# Altium schematic sheet Switches + Blocks.SchDoc("Switches + Blocks")
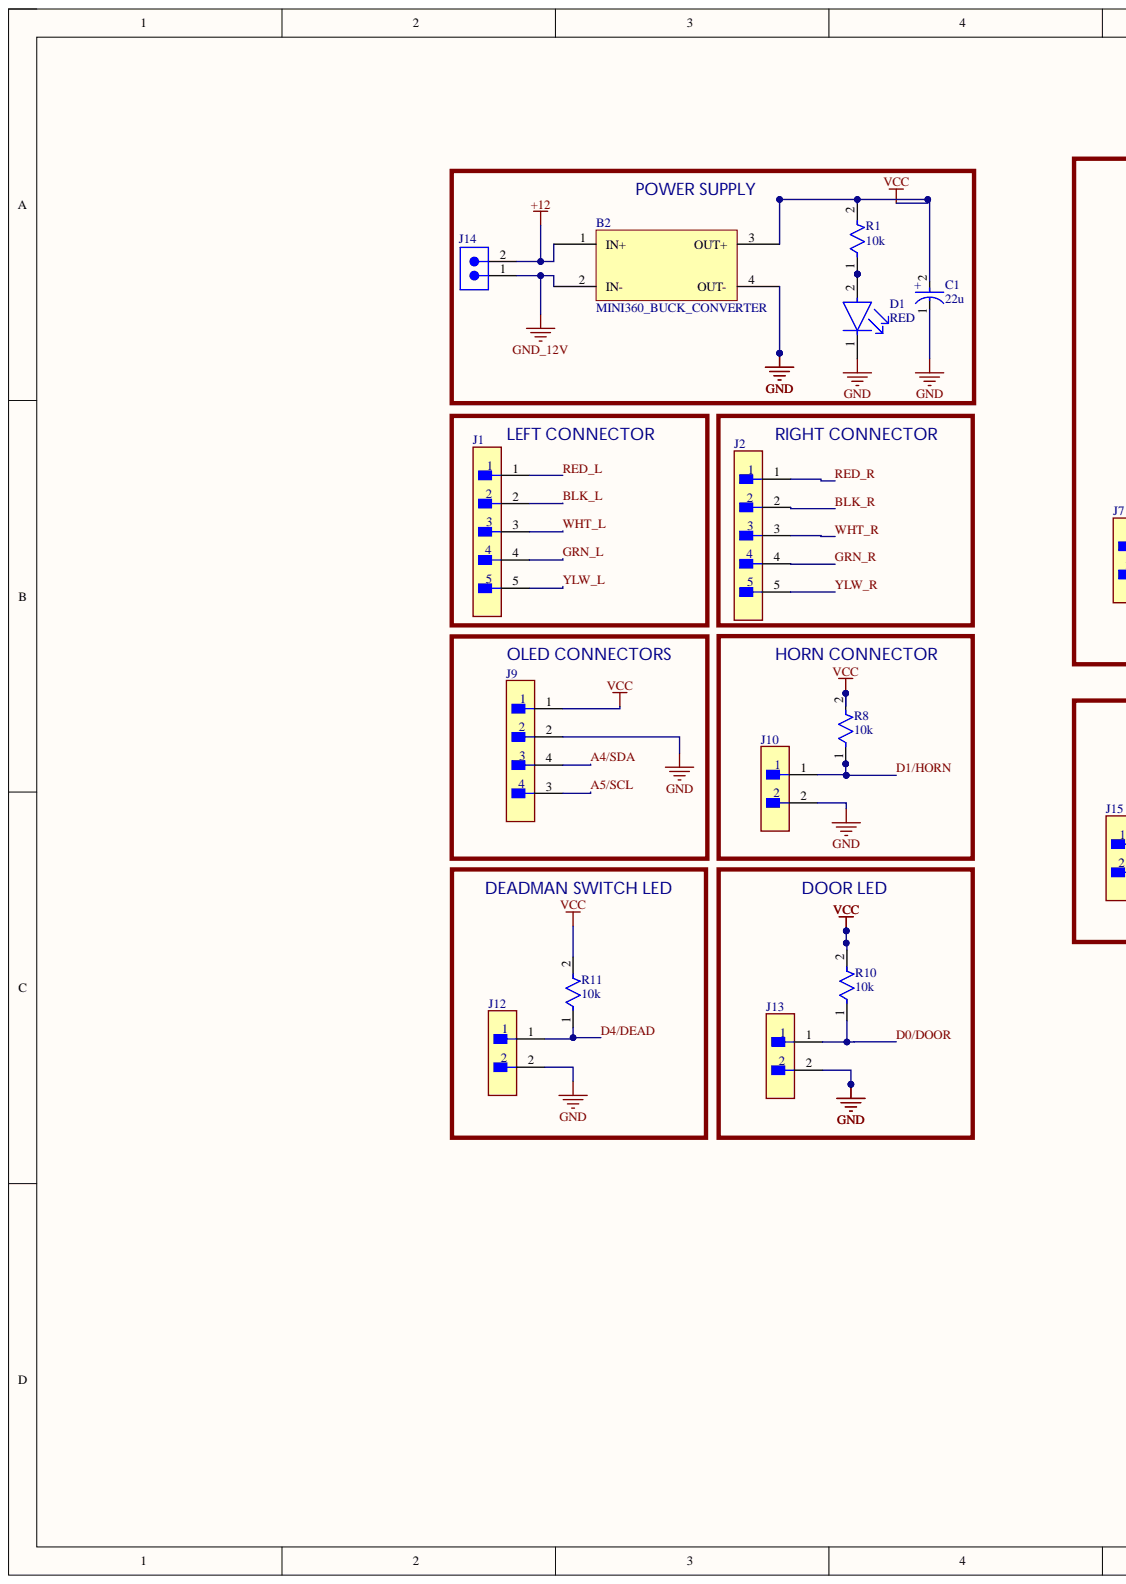
[diagram p0: Switches + Blocks.SchDoc, left]
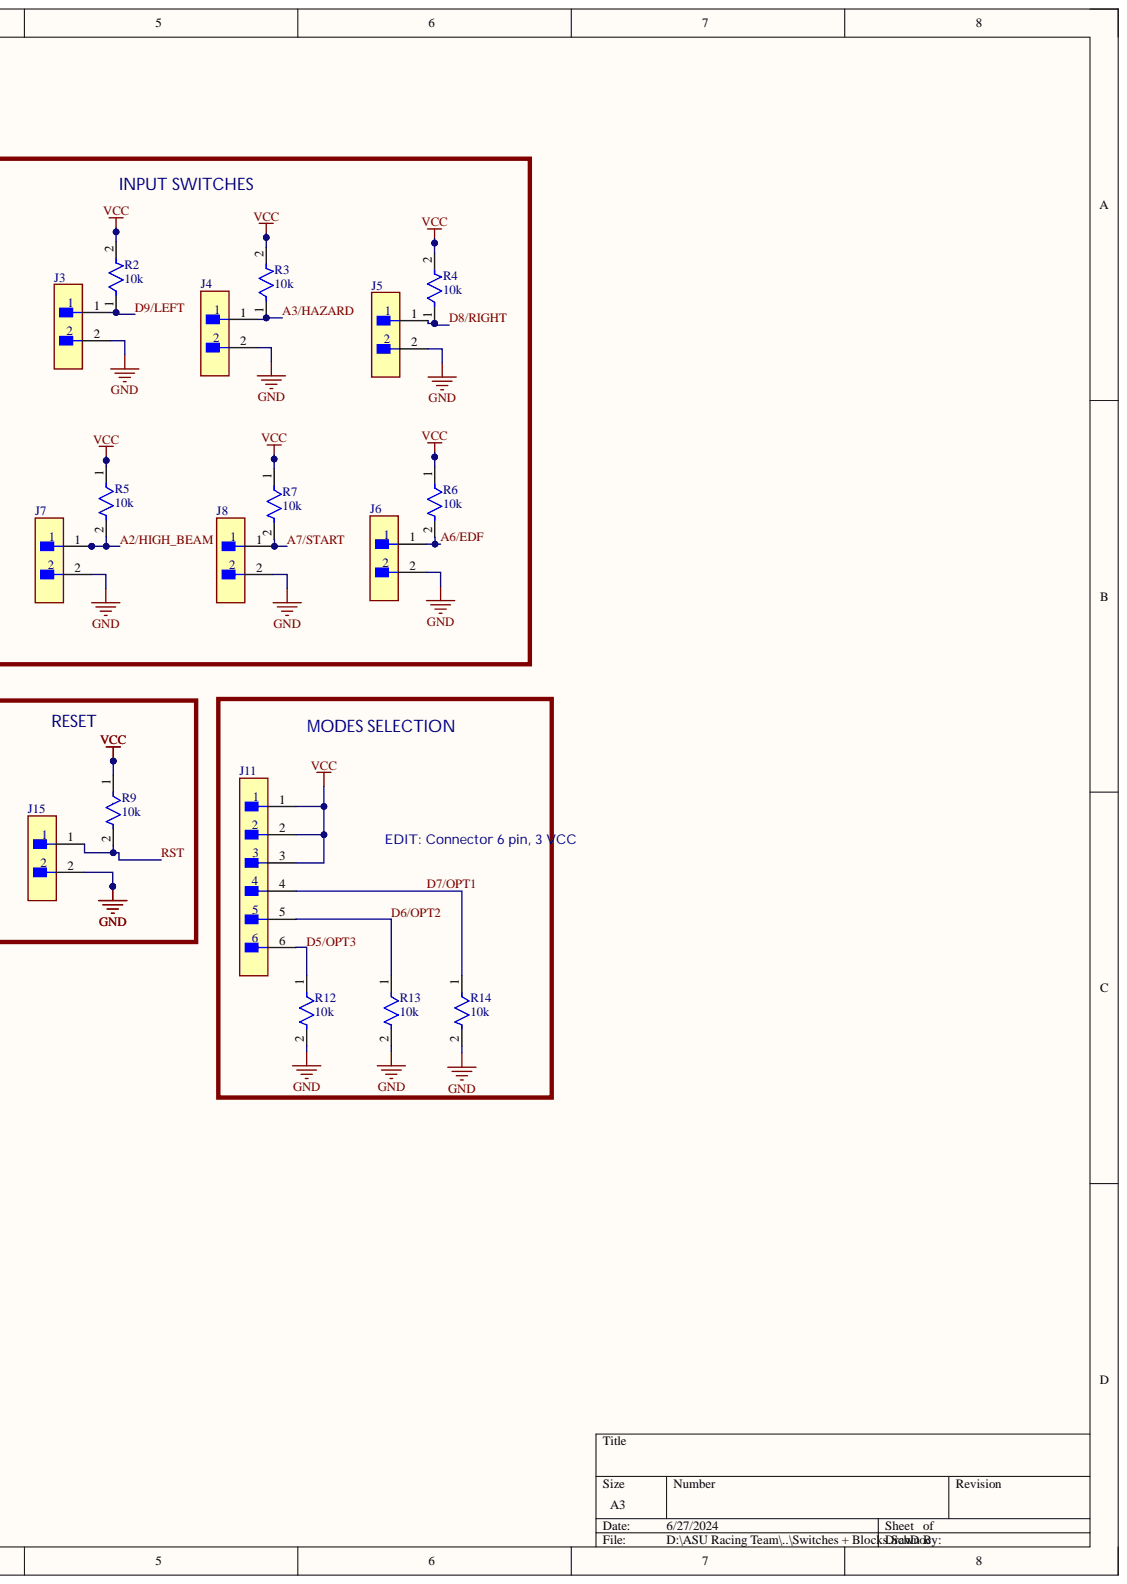
[diagram p1: Switches + Blocks.SchDoc, right]

## Parts
B2  MINI360_BUCK_CONVERTER  [MINI360_BUCK_CONVERTER]
C1  22u  [FP-RAD-TH-D_5_0_5-L_11_1-MFG]
D1  RED  [FP-6_544-5255_01-4-MFG]
J1  B5B-XH-AM(LF)(SN)  [FP-B5B-XH-AM_LF_SN-MFG]  (value not drawn)
J2  B5B-XH-AM(LF)(SN)  [FP-B5B-XH-AM_LF_SN-MFG]  (value not drawn)
J3  B2B-XH-A(LF)(SN)  [FP-B2B-XH-A_LF_SN-MFG]  (value not drawn)
J4  B2B-XH-A(LF)(SN)  [FP-B2B-XH-A_LF_SN-MFG]  (value not drawn)
J5  B2B-XH-A(LF)(SN)  [FP-B2B-XH-A_LF_SN-MFG]  (value not drawn)
J6  B2B-XH-A(LF)(SN)  [FP-B2B-XH-A_LF_SN-MFG]  (value not drawn)
J7  B2B-XH-A(LF)(SN)  [FP-B2B-XH-A_LF_SN-MFG]  (value not drawn)
J8  B2B-XH-A(LF)(SN)  [FP-B2B-XH-A_LF_SN-MFG]  (value not drawn)
J9  B4B-XH-A(LF)(SN)  [FP-B4B-XH-A_LF_SN-MFG]  (value not drawn)
J10  B2B-XH-A(LF)(SN)  [FP-B2B-XH-A_LF_SN-MFG]  (value not drawn)
J11  B6B-XH-AM(LF)(SN)  [FP-B6B-XH-AM_LF_SN-MFG]  (value not drawn)
J12  B2B-XH-A(LF)(SN)  [FP-B2B-XH-A_LF_SN-MFG]  (value not drawn)
J13  B2B-XH-A(LF)(SN)  [FP-B2B-XH-A_LF_SN-MFG]  (value not drawn)
J14  691312510002  [691312510002]  (value not drawn)
J15  B2B-XH-A(LF)(SN)  [FP-B2B-XH-A_LF_SN-MFG]  (value not drawn)
R1  10k  [PCBComponent_1]
R2  10k  [PCBComponent_1]
R3  10k  [PCBComponent_1]
R4  10k  [PCBComponent_1]
R5  10k  [PCBComponent_1]
R6  10k  [PCBComponent_1]
R7  10k  [PCBComponent_1]
R8  10k  [PCBComponent_1]
R9  10k  [PCBComponent_1]
R10  10k  [PCBComponent_1]
R11  10k  [PCBComponent_1]
R12  10k  [PCBComponent_1]
R13  10k  [PCBComponent_1]
R14  10k  [PCBComponent_1]

## Nets
+12: B2.1(IN+) J14.2
GND: B2.4(OUT-) C1.1 D1.1 J3.2 J4.2 J5.2 J6.2 J7.2 J8.2 J9.2 J10.2 J12.2 J13.2 J15.2 R12.2 R13.2 R14.2
GND_12V: B2.2(IN-) J14.1
VCC: B2.3(OUT+) C1.2 J9.1 J11.1 J11.2 J11.3 R1.2 R2.2 R3.2 R4.2 R5.1 R6.1 R7.1 R8.2 R9.1 R10.2 R11.2
A2/HIGH_BEAM: J7.1 R5.2
A3/HAZARD: J4.1 R3.1
A4/SDA: J9.4
A5/SCL: J9.3
A6/EDF: J6.1 R6.2
A7/START: J8.1 R7.2
BLK_L: J1.2
BLK_R: J2.2
D0/DOOR: J13.1 R10.1
D1/HORN: J10.1 R8.1
D4/DEAD: J12.1 R11.1
D5/OPT3: J11.6 R12.1
D6/OPT2: J11.5 R13.1
D7/OPT1: J11.4 R14.1
D8/RIGHT: J5.1 R4.1
D9/LEFT: J3.1 R2.1
GRN_L: J1.4
GRN_R: J2.4
RED_L: J1.1
RED_R: J2.1
RST: J15.1 R9.2
WHT_L: J1.3
WHT_R: J2.3
YLW_L: J1.5
YLW_R: J2.5
NetD1_2: D1.2 R1.1

## Library data of the parts
C1 = CMP-05427-001989-1: Aluminum Electrolytic Capacitors (Radial Lead Type) AEC-Q200 Qualified 22uF ±20% 50V; ESR (Equivalent Series Resistance): 1.3R; Lead Diameter: 0.5mm; Leakage Current: 3uA; Life (Hours): 1000Hour; Polarity: Polar; Ripple Current: 155mA; Capacitance: 22uF; Case/Package: Radial; Component Kind: Standard; Contact Plating: Tin; Diameter: 5mm; Features: General Purpose; Height: 11mm; Height - Seated (Max): 0.472inch; Impedance: 1.3R; Lead Pitch: 0.197inch; Length: 11mm; Library Name: Altium Content Vault; Max Operating Temperature: 105°C; Min Operating Temperature: -55°C; Mount: Through Hole; Package Quantity: 2000; Packaging: Tape and Reel; Radiation Hardening: No; RoHS Compliant: Yes; Series: FC; Termination: Radial; Tolerance: 20%; Voltage Rating: 50V; Voltage Rating (DC): 50V
D1 = CMP-00030-55433990-1: TLLG4400 Led, Pcb, Green, 0.63MCD, 3MM, T-1, 7MA, 1.9V, 565NM, 25DEG, 20V, 20MW, -40; Dominant Wavelength: 575nm; Forward Current: 2mA; Lens Style: Circular, Colored, Diffused, Dome, Round; Lens Transparency: Diffused; Luminous Intensity: 1.2mcd; Number of Elements: 1; Viewing Angle: 50°; Voltage Rating (DC): 2.4V; Case/Package: T; Color: Green; Component Kind: Standard; Depth: 3.2mm; Forward Voltage: 1.9V; Height: 4.5mm; Illumination Color: Green; Length: 3.2mm; Lens Color: Green; Lens Size: 3mm; Library Name: Altium Content Vault; Max Operating Temperature: 100°C; Max Power Dissipation: 20mW; Min Operating Temperature: -40°C; Mount: Through Hole; NexarPartId: 55433990; Number of LEDs: 1; Number of Pins: 2; Power Dissipation: 20mW; Radiation Hardening: No; REACH SVHC: Unknown; Reverse Voltage: 20V; RoHS: Compliant; Schedule B: 8541402000, 8541402000; Test Current: 2mA; Wavelength: 575nm
J1 J2 = CMP-17439-000304-1: CONN HEADER VERT 5POS 2.5MM; Contact Resistance: 20mR; Mated Stacking Heights: 9.8mm; Max Current Rating: 3A; Max Voltage Rating (AC): 250V; Number of Positions: 5; Color: Green; Component Kind: Standard; Connector Type: Header; Contact Finish - Mating: TIN; Contact Material: Brass; Contact Plating: Copper; Contact Shape: Square; Contact Type: Male Pin; Depth: 5.75mm; Fastening Type: Detent; Flammability Rating: UL94 V-0; Housing Color: White; Housing Material: Polyamide; Insulation Color: Natural; Insulation Material: Nylon; Lead Free: Lead Free; Length: 14.9mm; Library Name: Altium Content Vault; Max Operating Temperature: 85°C; Min Operating Temperature: -25°C; Mount: Through Hole; Number of Rows: 1; Orientation: Straight; Packaging: Bulk; Pitch: 2.5mm; Series: XH; Termination: Solder; Voltage Rating: 250V
J3-J8 J10 J12 J13 J15 = CMP-2000-05888-3: CONN HEADER VERT 2POS 2.5MM; Connector Type: Male Pin; Contact Resistance: 20mR; Current Rating: 3A; Insulation Resistance: 1GR; Max Voltage Rating (AC): 250V; Number of Contacts: 2; Wire/Cable Gauge: 22AWG; Color: Natural; Component Kind: Standard; Contact Material: Brass; Contact Plating: Tin; Contact Type: Male Pin; Depth: 5.75mm; Fastening Type: Detent; Housing Color: White; Housing Material: Polyamide; Lead Free: Lead Free; Lead Pitch: 2.5mm; Length: 7.4mm; Library Name: Altium Content Vault; Max Current Rating: 3A; Max Operating Temperature: 85°C; Max Voltage Rating (DC): 250V; Min Operating Temperature: -25°C; Mount: Through Hole; Number of Positions: 2; Number of Rows: 1; Orientation: Straight; Packaging: Bulk; Pitch: 2.5mm; REACH SVHC: No SVHC; Series: XH; Termination: Solder; Voltage Rating: 250V; Voltage Rating (AC): 250V
J9 = CMP-17439-000250-1: CONN HEADER VERT 4POS 2.5MM; Contact Resistance: 20mR; Current Rating: 3A; Gender: Male; Insulation Resistance: 1GR; Mated Stacking Heights: 9.8mm; Max Voltage Rating (AC): 250V; Number of Contacts: 4; Wire/Cable Gauge: 22AWG; Color: Natural; Component Kind: Standard; Connector Type: Header; Contact Finish - Mating: TIN; Contact Material: Brass; Contact Plating: Tin; Contact Shape: Square; Contact Type: Male Pin; Depth: 5.75mm; Fastening Type: Detent; Flammability Rating: UL94 V-0; Housing Color: White; Housing Material: Polyamide; Insulation Color: Natural; Insulation Material: Nylon; Lead Free: Lead Free; Lead Pitch: 2.5mm; Length: 12.4mm; Library Name: Altium Content Vault; Material: Polyamide; Max Current Rating: 3A; Max Operating Temperature: 85°C; Max Voltage Rating (DC): 250V; Min Operating Temperature: -25°C; Mount: Through Hole; Number of Positions: 4; Number of Rows: 1; Orientation: Straight; Package Quantity: 500; Packaging: Bulk; Pitch: 2.5mm; REACH SVHC: No SVHC; Series: XH; Termination: Solder; Voltage Rating: 250V; Voltage Rating (AC): 250V
J11 = CMP-17439-000167-1: CONN HEADER VERT 6POS 2.5MM; Current Rating: 3A; Mated Stacking Heights: 9.8mm; Number of Poles: 6; Shape: Straight; Voltage Rating: 250V; Component Kind: Standard; Connector Type: Header; Contact Finish - Mating: TIN; Contact Material: Brass; Contact Plating: Copper; Contact Shape: Square; Contact Type: Male Pin; Fastening Type: Detent; Flammability Rating: UL94 V-0; Height: 7mm; Insulation Color: Natural; Insulation Material: Nylon; Length: 17.4mm; Library Name: Altium Content Vault; Max Operating Temperature: 85°C; Min Operating Temperature: -25°C; Mount: Through Hole; Number of Pins: 6; Number of Positions: 6; Number of Rows: 1; Packaging: Bulk; Pitch: 2.5mm; Series: XH; Termination: Press-Fit; Width: 5.75mm
J14 = CMP-1502-02169-2: NexarPartId: 8917753; Current: 20A; Gender: Male; Number of Contacts: 2; Number of Levels: 1; Pitch: 5.08mm; Voltage: 300V; Color: Green; Component Kind: Standard; Contact Material: Brass; Contact Plating: Tin; Height: 12mm; Lead Pitch: 5.08mm; Length: 11.5mm; Library Name: Altium Content Vault; Mount: Through Hole; Number of Pins: 2; Number of Positions Per Level: 2; Number of Rows: 1; Packaging: Box; REACH SVHC: Unknown; RoHS: Compliant; Termination: Solder; Width: 10.16mm
R1-R14 = RES: Component Kind: Standard; Library Name: RES_0.125W_AXIAL.IntLib
B2 = MINI360_BUCK_CONVERTER: Component Kind: Standard; Library Name: Buck_Converter.IntLib
